# Altium schematic sheet km_kl10.SchDoc
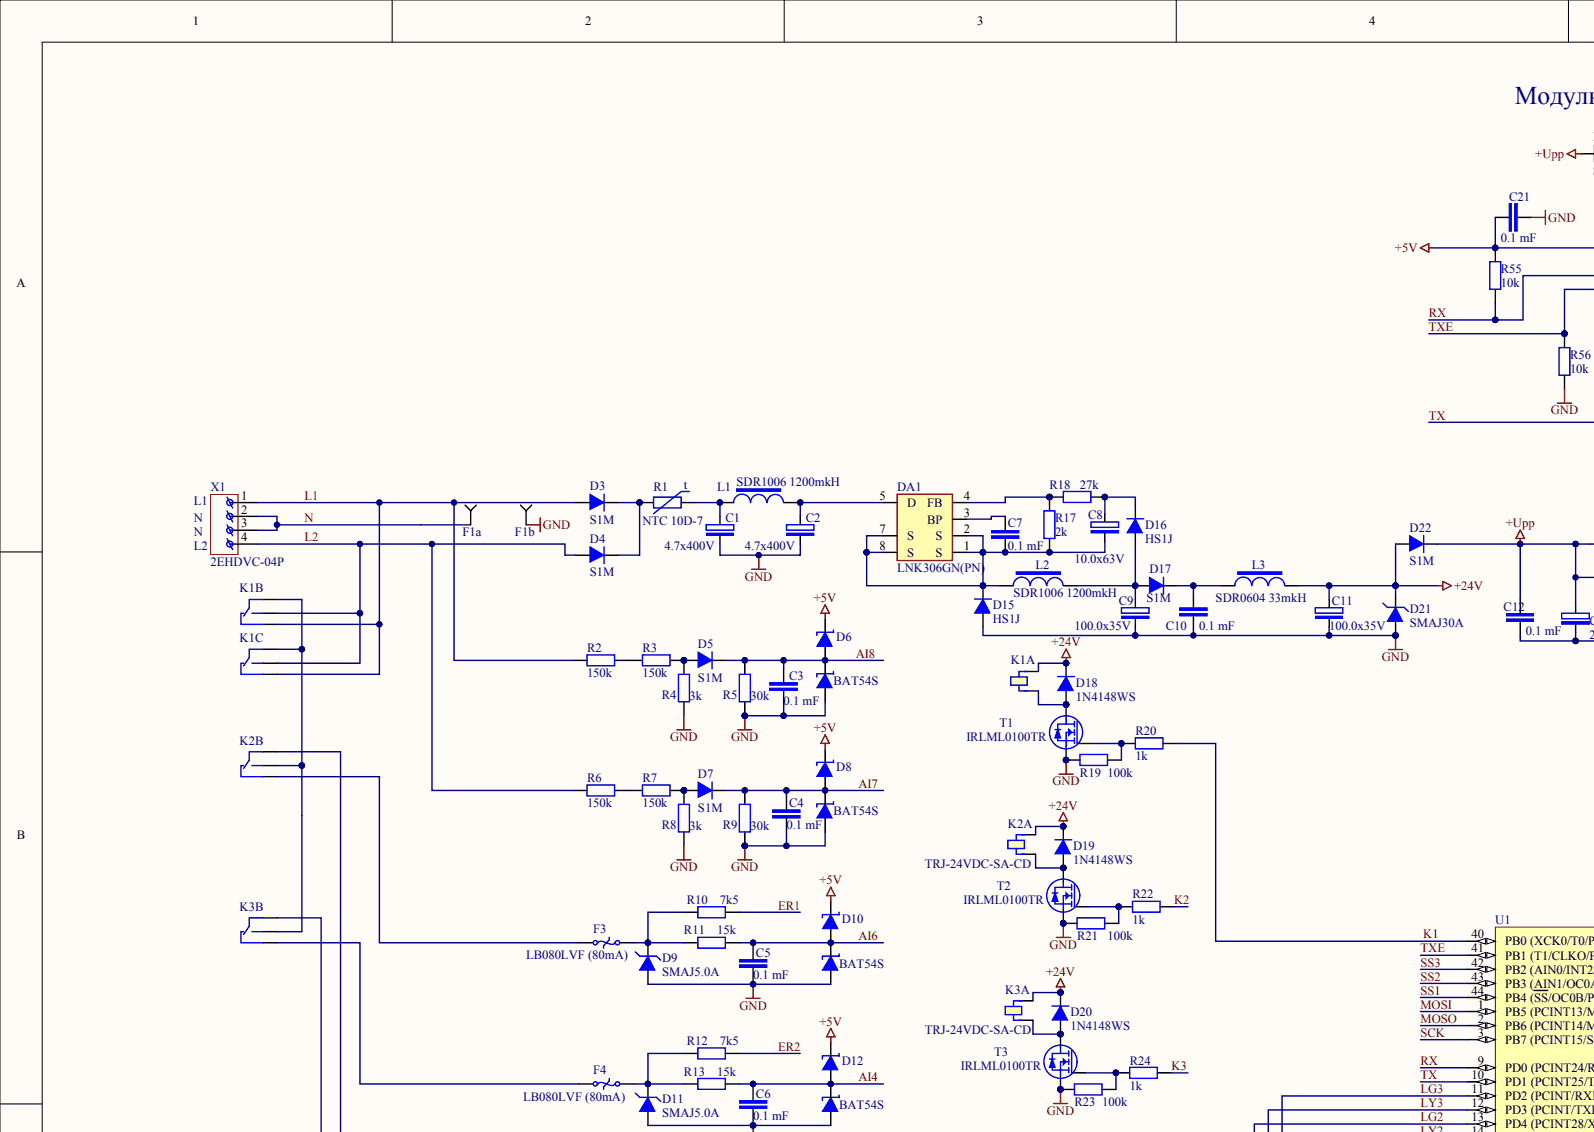
[diagram p0: km_kl10.SchDoc, top left]
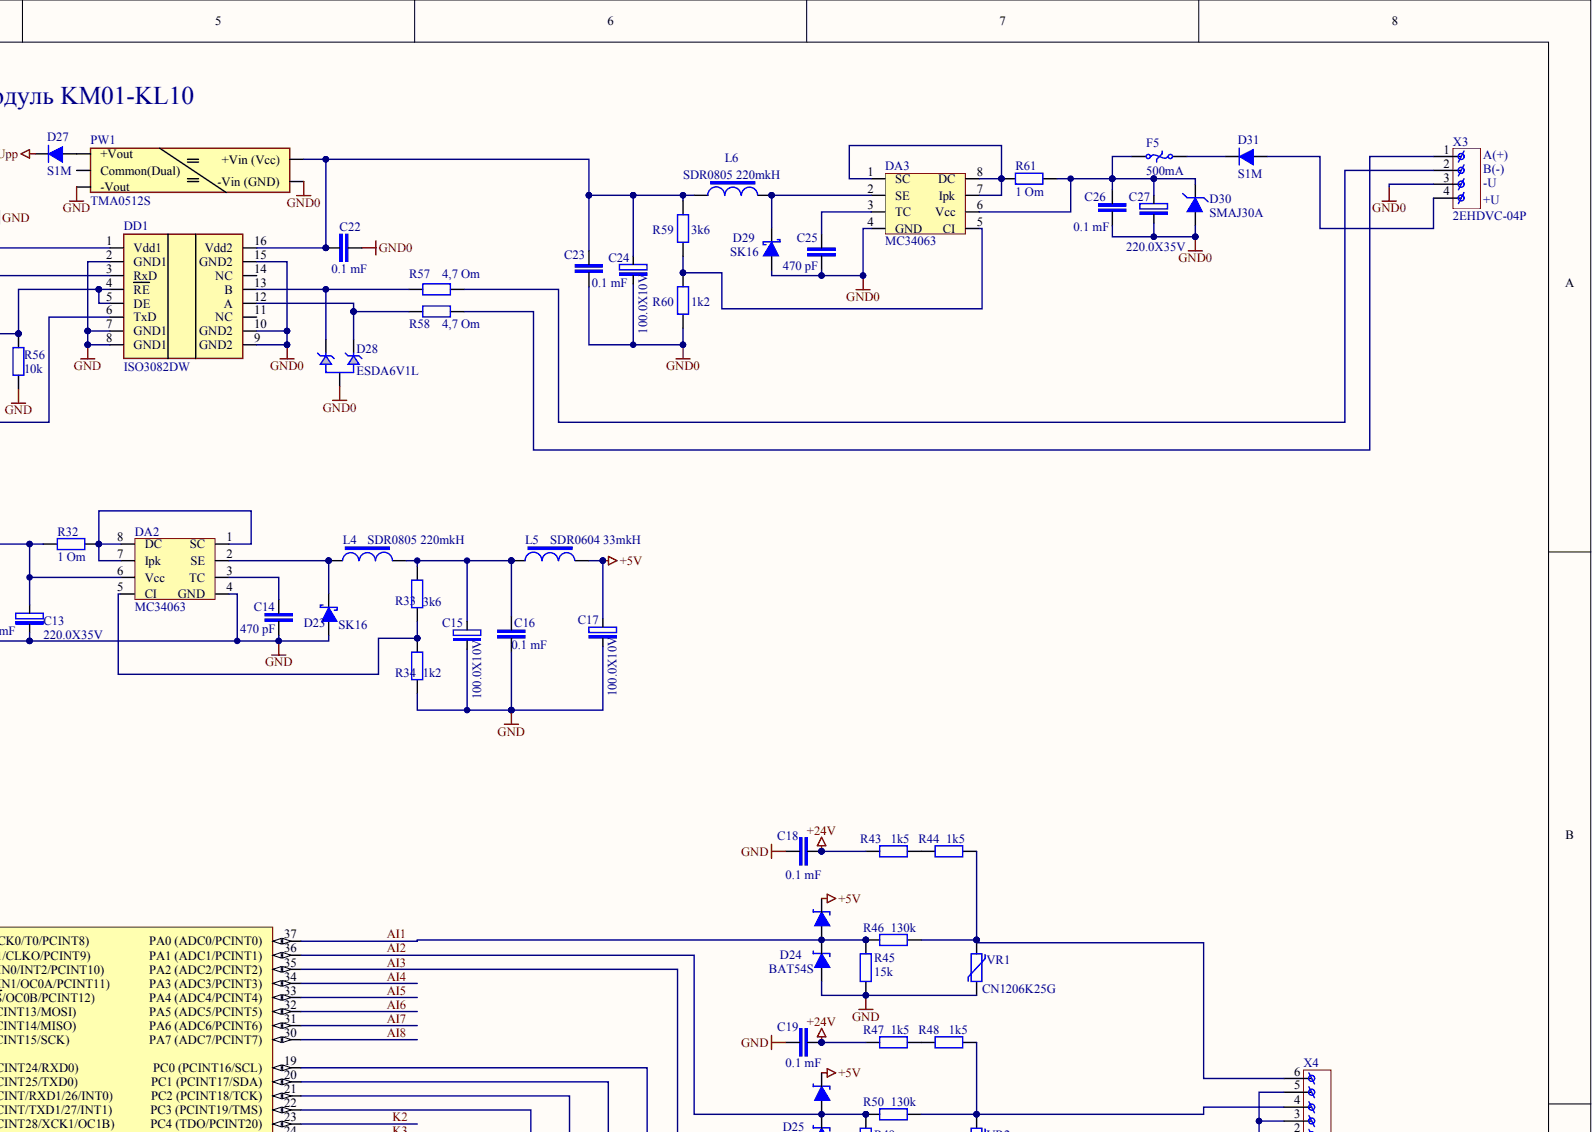
[diagram p1: km_kl10.SchDoc, top right]
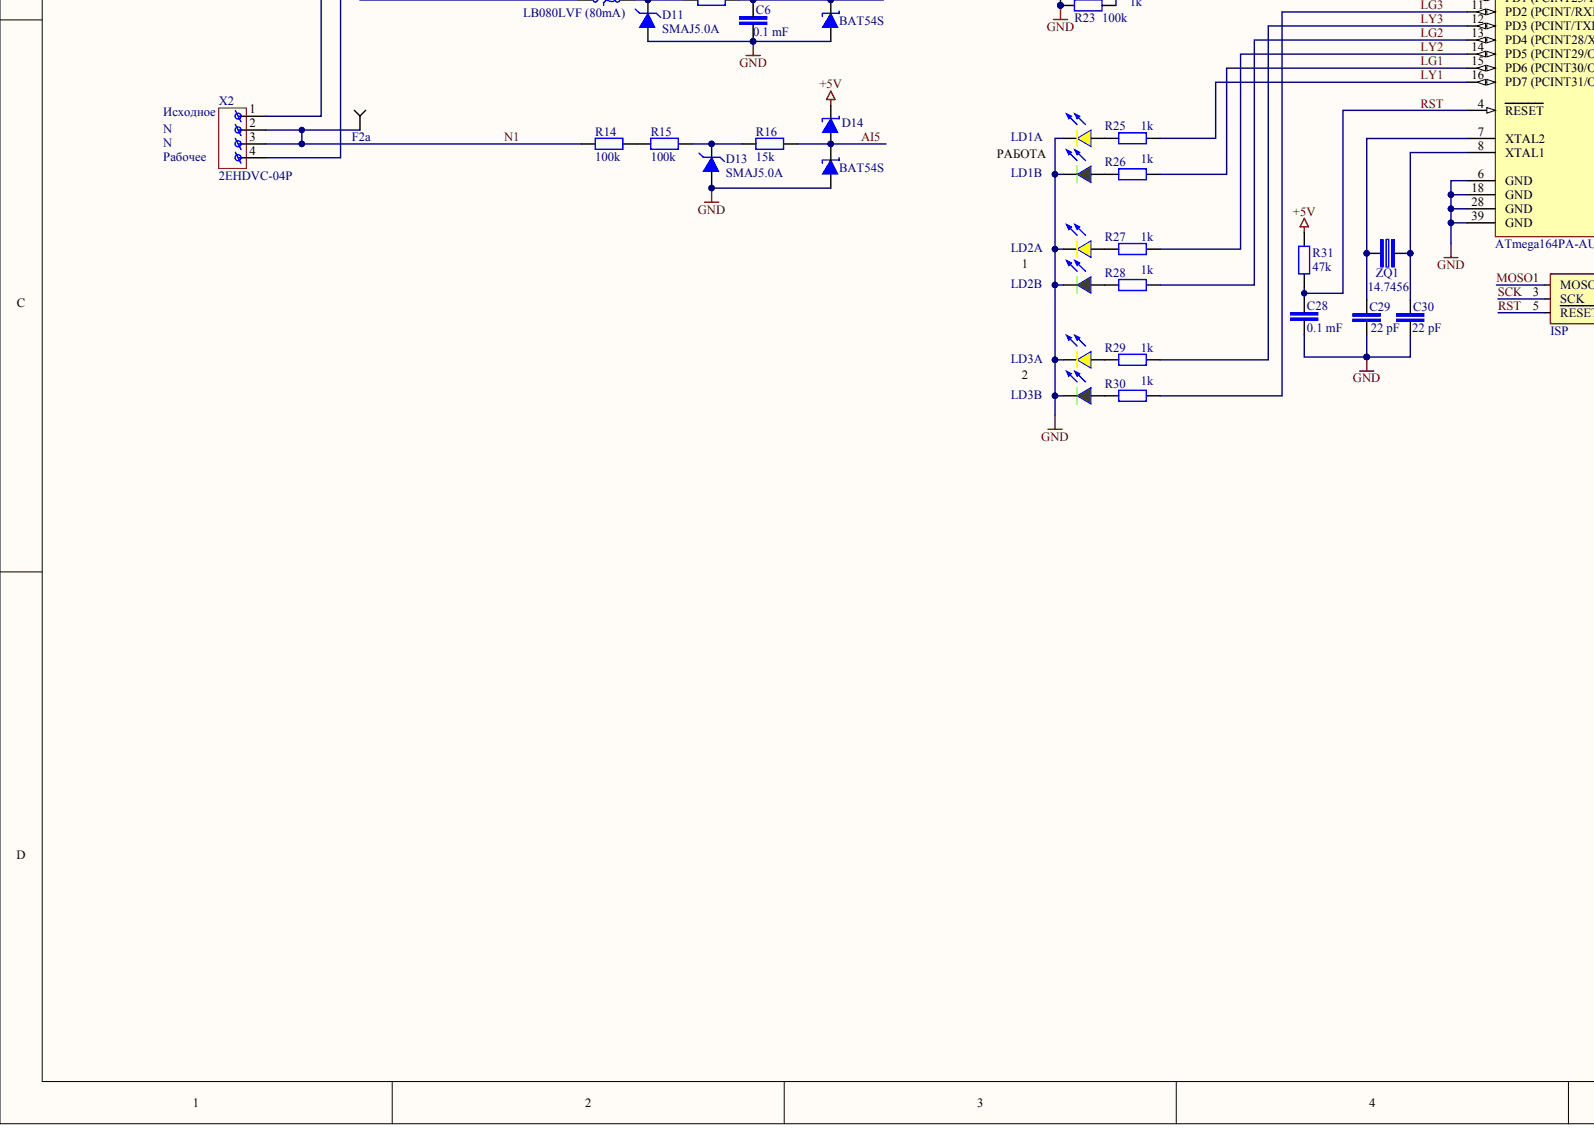
[diagram p2: km_kl10.SchDoc, bottom left]
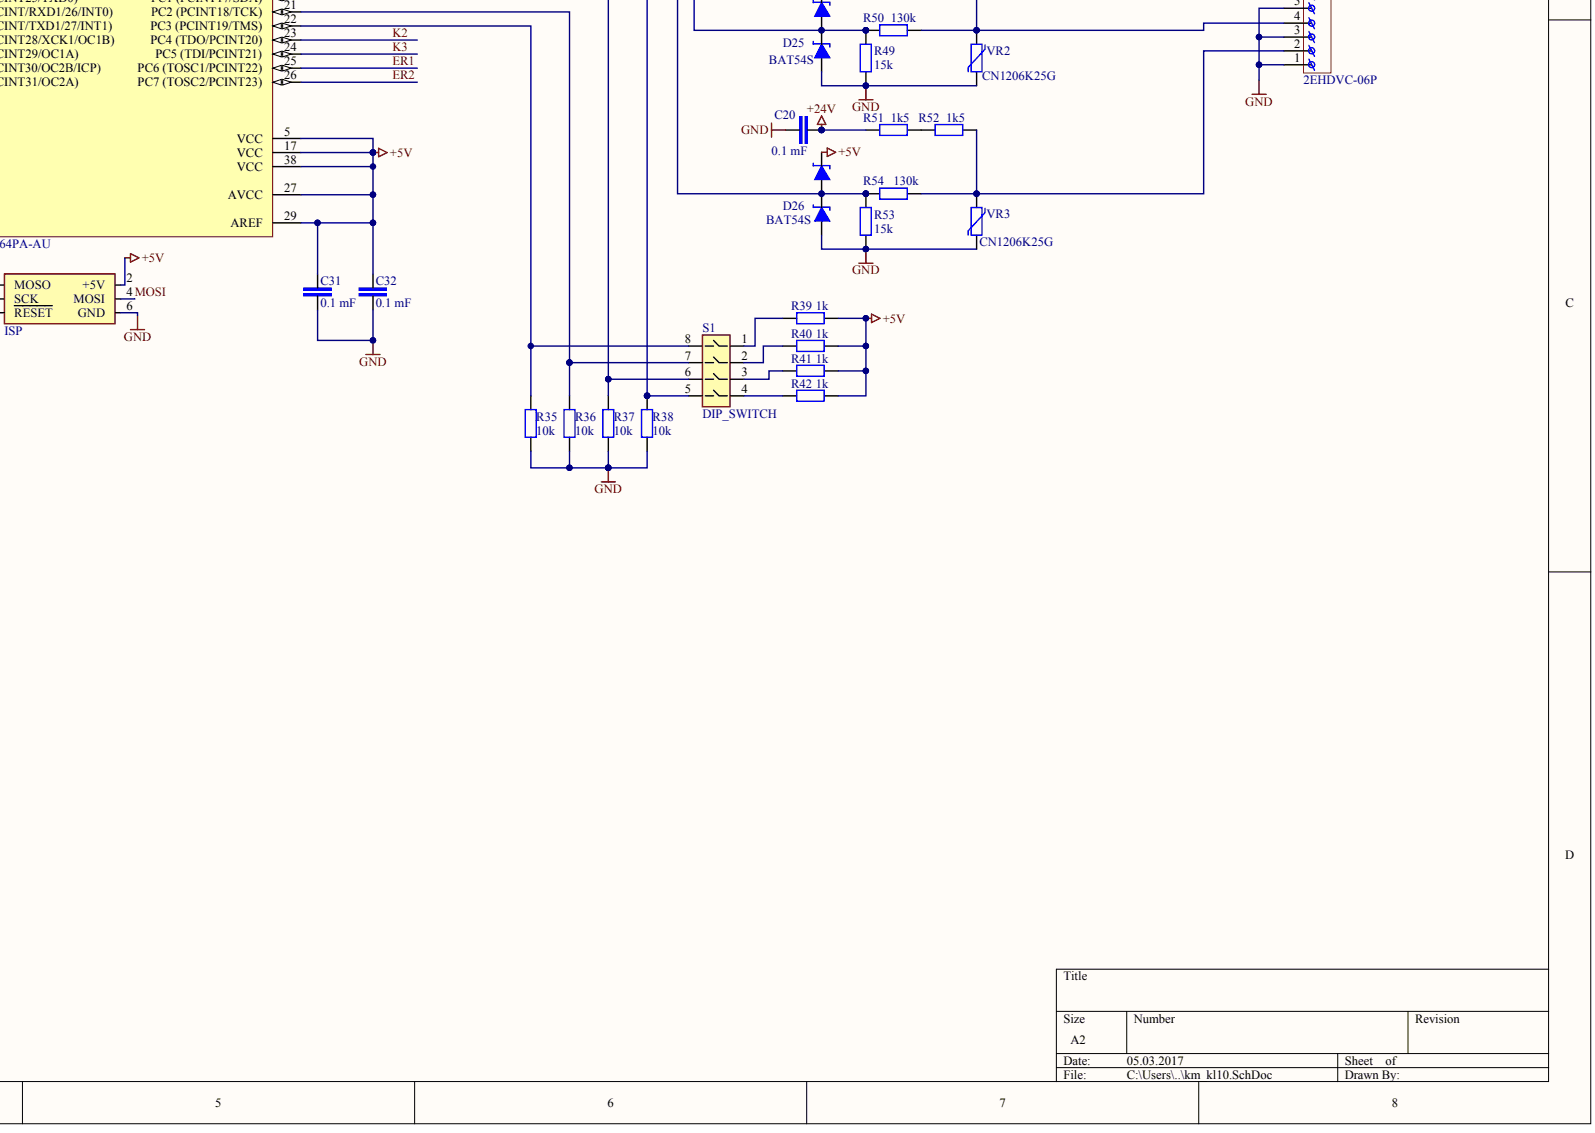
[diagram p3: km_kl10.SchDoc, bottom right]

## Parts
C1  4.7x400V
C2  4.7x400V
C3  0.1 mF
C4  0.1 mF
C5  0.1 mF
C6  0.1 mF
C7  0.1 mF
C8  10.0x63V
C9  100.0x35V
C10  0.1 mF
C11  100.0x35V
C12  0.1 mF
C13  220.0X35V
C14  470 pF
C15  100.0X10V
C16  0.1 mF
C17  100.0X10V
C18  0.1 mF
C19  0.1 mF
C20  0.1 mF
C21  0.1 mF
C22  0.1 mF
C23  0.1 mF
C24  100.0X10V
C25  470 pF
C26  0.1 mF
C27  220.0X35V
C28  0.1 mF
C29  22 pF
C30  22 pF
C31  0.1 mF
C32  0.1 mF
D3  S1M
D4  S1M
D5  S1M
D6  BAT54S
D7  S1M
D8  BAT54S
D9  SMAJ5.0A
D10  BAT54S
D11  SMAJ5.0A
D12  BAT54S
D13  SMAJ5.0A
D14  BAT54S
D15  HS1J
D16  HS1J
D17  S1M
D18  1N4148WS
D19  1N4148WS
D20  1N4148WS
D21  SMAJ30A
D22  S1M
D23  SK16
D24  BAT54S
D25  BAT54S
D26  BAT54S
D27  S1M
D28  ESDA6V1L
D29  SK16
D30  SMAJ30A
D31  S1M
DA1  LNK306GN(PN)
DA2  MC34063
DA3  MC34063
DD1  ISO3082DW
F1a  Conn_1  (value not drawn)
F1b  Conn_1  (value not drawn)
F2a  Conn_1  (value not drawn)
F3  LB080LVF (80mA)
F4  LB080LVF (80mA)
F5  500mA
ISP  ISP
K1  Rele_relpol  (value not drawn)  (parts A,B,C)
K2  TRJ-24VDC-SA-CD  (parts A,B)
K3  TRJ-24VDC-SA-CD  (parts A,B)
L1  SDR1006 1200mkH
L2  SDR1006 1200mkH
L3  SDR0604 33mkH
L4  SDR0805 220mkH
L5  SDR0604 33mkH
L6  SDR0805 220mkH
LD1  KAA-3528  (value not drawn)  (parts A,B)
LD2  KAA-3528  (value not drawn)  (parts A,B)
LD3  KAA-3528  (value not drawn)  (parts A,B)
PW1  TMA0512S
R1  NTC 10D-7
R2  150k
R3  150k
R4  3k
R5  30k
R6  150k
R7  150k
R8  3k
R9  30k
R10  7k5
R11  15k
R12  7k5
R13  15k
R14  100k
R15  100k
R16  15k
R17  2k
R18  27k
R19  100k
R20  1k
R21  100k
R22  1k
R23  100k
R24  1k
R25  1k
R26  1k
R27  1k
R28  1k
R29  1k
R30  1k
R31  47k
R32  1 Om
R33  3k6
R34  1k2
R35  10k
R36  10k
R37  10k
R38  10k
R39  1k
R40  1k
R41  1k
R42  1k
R43  1k5
R44  1k5
R45  15k
R46  130k
R47  1k5
R48  1k5
R49  15k
R50  130k
R51  1k5
R52  1k5
R53  15k
R54  130k
R55  10k
R56  10k
R57  4,7 Om
R58  4,7 Om
R59  3k6
R60  1k2
R61  1 Om
S1  DIP_SWITCH
T1  IRLML0100TR
T2  IRLML0100TR
T3  IRLML0100TR
U1  ATmega164PA-AU
VR1  CN1206K25G
VR2  CN1206K25G
VR3  CN1206K25G
X1  2EHDVC-04P
X2  2EHDVC-04P
X3  2EHDVC-04P
X4  2EHDVC-06P
ZQ1  14.7456

## Nets
+5V: C17.1 C21.2 C31.2 C32.2 D6.2 D8.2 D10.2 D12.2 D14.2 D24.2 D25.2 D26.2 DD1.1(Vdd1) ISP.2(+5V) L5.1 R31.2 R39.1 R40.1 R41.1 R42.1 R55.2 U1.5(VCC) U1.17(VCC) U1.27(AVCC) U1.29(AREF) U1.38(VCC)
+24V: C11.1 C18.2 C19.2 C20.2 D18.2 D19.2 D20.2 D21.2 D22.1 K1.1 K2.1 K3.1 L3.1 R43.2 R47.2 R51.2
+UPP: C12.2 C13.1 D22.2 D27.2 DA2.6(Vcc) R32.2
GND: C1.2 C2.2 C3.1 C4.1 C5.1 C6.1 C9.2 C10.1 C11.2 C12.1 C13.2 C14.2 C15.2 C16.1 C17.2 C18.1 C19.1 C20.1 C21.1 C28.1 C29.1 C30.1 C31.1 C32.1 D6.1 D8.1 D9.1 D10.1 D11.1 D12.1 D13.1 D14.1 D15.1 D21.1 D23.1 D24.1 D25.1 D26.1 DA2.4(GND) DD1.2(GND1) DD1.7(GND1) DD1.8(GND1) F1b.1 ISP.6(GND) LD1.1 LD1.3 LD2.1 LD2.3 LD3.1 LD3.3 PW1.3(-Vout) R4.1 R5.1 R8.1 R9.1 R19.1 R21.1 R23.1 R34.1 R35.2 R36.2 R37.2 R38.2 R45.1 R49.1 R53.1 R56.1 T1.2 T2.2 T3.2 U1.6(GND) U1.18(GND) U1.28(GND) U1.39(GND) VR1.1 VR2.1 VR3.1 X4.1 X4.3 X4.5
GND0: C22.1 C23.1 C24.2 C25.2 C26.2 C27.2 D28.3 D29.1 D30.1 DA3.4(GND) DD1.9(GND2) DD1.10(GND2) DD1.15(GND2) PW1.2(-Vin_(GND)) R60.1 X3.3
AI1: D24.3 R45.2 R46.2 U1.37(PA0_(ADC0/PCINT0))
AI2: D25.3 R49.2 R50.2 U1.36(PA1_(ADC1/PCINT1))
AI3: D26.3 R53.2 R54.2 U1.35(PA2_(ADC2/PCINT2))
AI4: C6.2 D12.3 R13.1 U1.34(PA3_(ADC3/PCINT3))
AI5: D14.3 R16.1 U1.33(PA4_(ADC4/PCINT4))
AI6: C5.2 D10.3 R11.1 U1.32(PA5_(ADC5/PCINT5))
AI7: C4.2 D7.2 D8.3 R9.2 U1.31(PA6_(ADC6/PCINT6))
AI8: C3.2 D5.2 D6.3 R5.2 U1.30(PA7_(ADC7/PCINT7))
ER1: R10.2 U1.25(PC6_(TOSC1/PCINT22))
ER2: R12.2 U1.26(PC7_(TOSC2/PCINT23))
K1: R20.2 U1.40(PB0_(XCK0/T0/PCINT8))
K2: R22.2 U1.23(PC4_(TDO/PCINT20))
K3: R24.2 U1.24(PC5_(TDI/PCINT21))
L1: D3.1 K1.3 K1.6 R2.1 X1.1
L2: D4.1 K1.5 K1.8 R6.1 X1.4
LG1: R26.2 U1.15(PD6_(PCINT30/OC2B/ICP))
LG2: R28.2 U1.13(PD4_(PCINT28/XCK1/OC1B))
LG3: R30.2 U1.11(PD2_(PCINT/RXD1/26/INT0))
LY1: R25.2 U1.16(PD7_(PCINT31/OC2A))
LY2: R27.2 U1.14(PD5_(PCINT29/OC1A))
LY3: R29.2 U1.12(PD3_(PCINT/TXD1/27/INT1))
MOSI: ISP.4(MOSI) U1.1(PB5_(PCINT13/MOSI))
MOSO: ISP.1(MOSO) U1.2(PB6_(PCINT14/MISO))
N: F1a.1 X1.2 X1.3
N1: F2a.1 R14.1 X2.2 X2.3
RST: C28.2 ISP.5(RESET) R31.1 U1.4(RESET)
RX: DD1.3(RxD) R55.1 U1.9(PD0_(PCINT24/RXD0))
SCK: ISP.3(SCK) U1.3(PB7_(PCINT15/SCK))
SS1: U1.44(PB4_(SS/OC0B/PCINT12))
SS2: U1.43(PB3_(AIN1/OC0A/PCINT11))
SS3: U1.42(PB2_(AIN0/INT2/PCINT10))
TX: DD1.6(TxD) U1.10(PD1_(PCINT25/TXD0))
TXE: DD1.4(RE) DD1.5(DE) R56.2 U1.41(PB1_(T1/CLKO/PCINT9))
NetC1_1: C1.1 L1.2 R1.2
NetC2_1: C2.1 DA1.5(D) L1.1
NetC7_1: C7.1 C8.2 D15.2 DA1.1(S) DA1.2(S) DA1.7(S) DA1.8(S) L2.2 R17.1
NetC7_2: C7.2 DA1.3(BP)
NetC8_1: C8.1 D16.2 R18.1
NetC9_1: C9.1 D16.1 D17.1 L2.1
NetC10_2: C10.2 D17.2 L3.2
NetC14_1: C14.1 DA2.3(TC)
NetC15_1: C15.1 C16.2 L4.2 L5.2 R33.2
NetC22_2: C22.2 C23.2 C24.1 DD1.16(Vdd2) L6.2 PW1.1(+Vin_(Vcc)) R59.2
NetC25_1: C25.1 DA3.3(TC)
NetC26_1: C26.1 C27.1 D30.2 DA3.6(Vcc) F5.1 R61.2
NetC29_2: C29.2 U1.7(XTAL2) ZQ1.1
NetC30_2: C30.2 U1.8(XTAL1) ZQ1.2
NetD3_2: D3.2 D4.2 R1.1
NetD5_1: D5.1 R3.2 R4.2
NetD7_1: D7.1 R7.2 R8.2
NetD9_2: D9.2 F3.2 R10.1 R11.2
NetD11_2: D11.2 F4.2 R12.1 R13.2
NetD13_2: D13.2 R15.2 R16.2
NetD18_1: D18.1 K1.2 T1.3
NetD19_1: D19.1 K2.5 T2.3
NetD20_1: D20.1 K3.5 T3.3
NetD23_2: D23.2 DA2.2(SE) L4.1
NetD27_1: D27.1 PW1.5(+Vout)
NetD28_1: D28.1 DD1.12(A) R58.2
NetD28_2: D28.2 DD1.13(B) R57.2
NetD29_2: D29.2 DA3.2(SE) L6.1
NetD31_1: D31.1 X3.4
NetD31_2: D31.2 F5.2
NetDA1_4: DA1.4(FB) R17.2 R18.2
NetDA2_1: DA2.1(SC) DA2.7(Ipk) DA2.8(DC) R32.1
NetDA2_5: DA2.5(CI) R33.1 R34.2
NetDA3_1: DA3.1(SC) DA3.7(Ipk) DA3.8(DC) R61.1
NetDA3_5: DA3.5(CI) R59.1 R60.2
NetF3_1: F3.1 K2.4
NetF4_1: F4.1 K3.4
NetK1_4: K1.4 K1.7 K2.3 K3.3
NetK2_2: K2.2 X2.4
NetK3_2: K3.2 X2.1
NetLD1_2: LD1.2 R25.1
NetLD1_4: LD1.4 R26.1
NetLD2_2: LD2.2 R27.1
NetLD2_4: LD2.4 R28.1
NetLD3_2: LD3.2 R29.1
NetLD3_4: LD3.4 R30.1
NetR2_2: R2.2 R3.1
NetR6_2: R6.2 R7.1
NetR14_2: R14.2 R15.1
NetR19_2: R19.2 R20.1 T1.1
NetR21_2: R21.2 R22.1 T2.1
NetR23_2: R23.2 R24.1 T3.1
NetR35_1: R35.1 S1.8 U1.22(PC3_(PCINT19/TMS))
NetR36_1: R36.1 S1.7 U1.21(PC2_(PCINT18/TCK))
NetR37_1: R37.1 S1.6 U1.20(PC1_(PCINT17/SDA))
NetR38_1: R38.1 S1.5 U1.19(PC0_(PCINT16/SCL))
NetR39_2: R39.2 S1.1
NetR40_2: R40.2 S1.2
NetR41_2: R41.2 S1.3
NetR42_2: R42.2 S1.4
NetR43_1: R43.1 R44.2
NetR44_1: R44.1 R46.1 VR1.2 X4.6
NetR47_1: R47.1 R48.2
NetR48_1: R48.1 R50.1 VR2.2 X4.4
NetR51_1: R51.1 R52.2
NetR52_1: R52.1 R54.1 VR3.2 X4.2
NetR57_1: R57.1 X3.2
NetR58_1: R58.1 X3.1

Not connected: DD1 11(NC) 14(NC); PW1 4(Common(Dual))

## Library data of the parts
C1-C32 D3-D31 DA1-DA3 DD1 F1a F1b F2a F3-F5 ISP K1-K3 L1-L6 LD1-LD3 PW1 R1-R61 S1 T1-T3 U1 VR1-VR3 X1-X4 ZQ1 = (no symbol name)
  U1: Published: 1-Apr-2010; DatasheetVersion: revA, Jan-2010; PackageVersion: rev.B, Oct-2001; PackageReference: 44A; PackageDescription: 44-Pin Plastic Thin Profile Quad Flat Pack, 10 x 10 x 1.0 mm Body, 0.8 mm Pitch (TQFP); Supplier 1: Digi-Key; Supplier Part Number 1: ATMEGA164PA-AU-ND; Supplier 2: Farnell; Supplier Part Number 2: 1748513; Supplier 3: Mouser; Supplier Part Number 3: 556-ATMEGA164PA-AU; ComponentLink1Description: Manufacturer Link; ComponentLink1URL: http://www.atmel.com/products/avr/default.asp?; ComponentLink2Description: ATmega164A/164PA/324A/324PA/644A/644PA/1284/1284P Datasheet; ComponentLink2URL: http://www.atmel.com/dyn/resources/prod_documents/doc8272.pdf; ComponentLink3Description: ATmega164A/164PA/324A/324PA/644A/644PA/1284/1284P Summary; ComponentLink3URL: http://www.atmel.com/dyn/resources/prod_documents/8272S.pdf; ComponentLink4Description: Atmel.com Home; ComponentLink4URL: http://www.atmel.com; Publisher: Altium Limited
